# Altium schematic sheet outputs.SchDoc
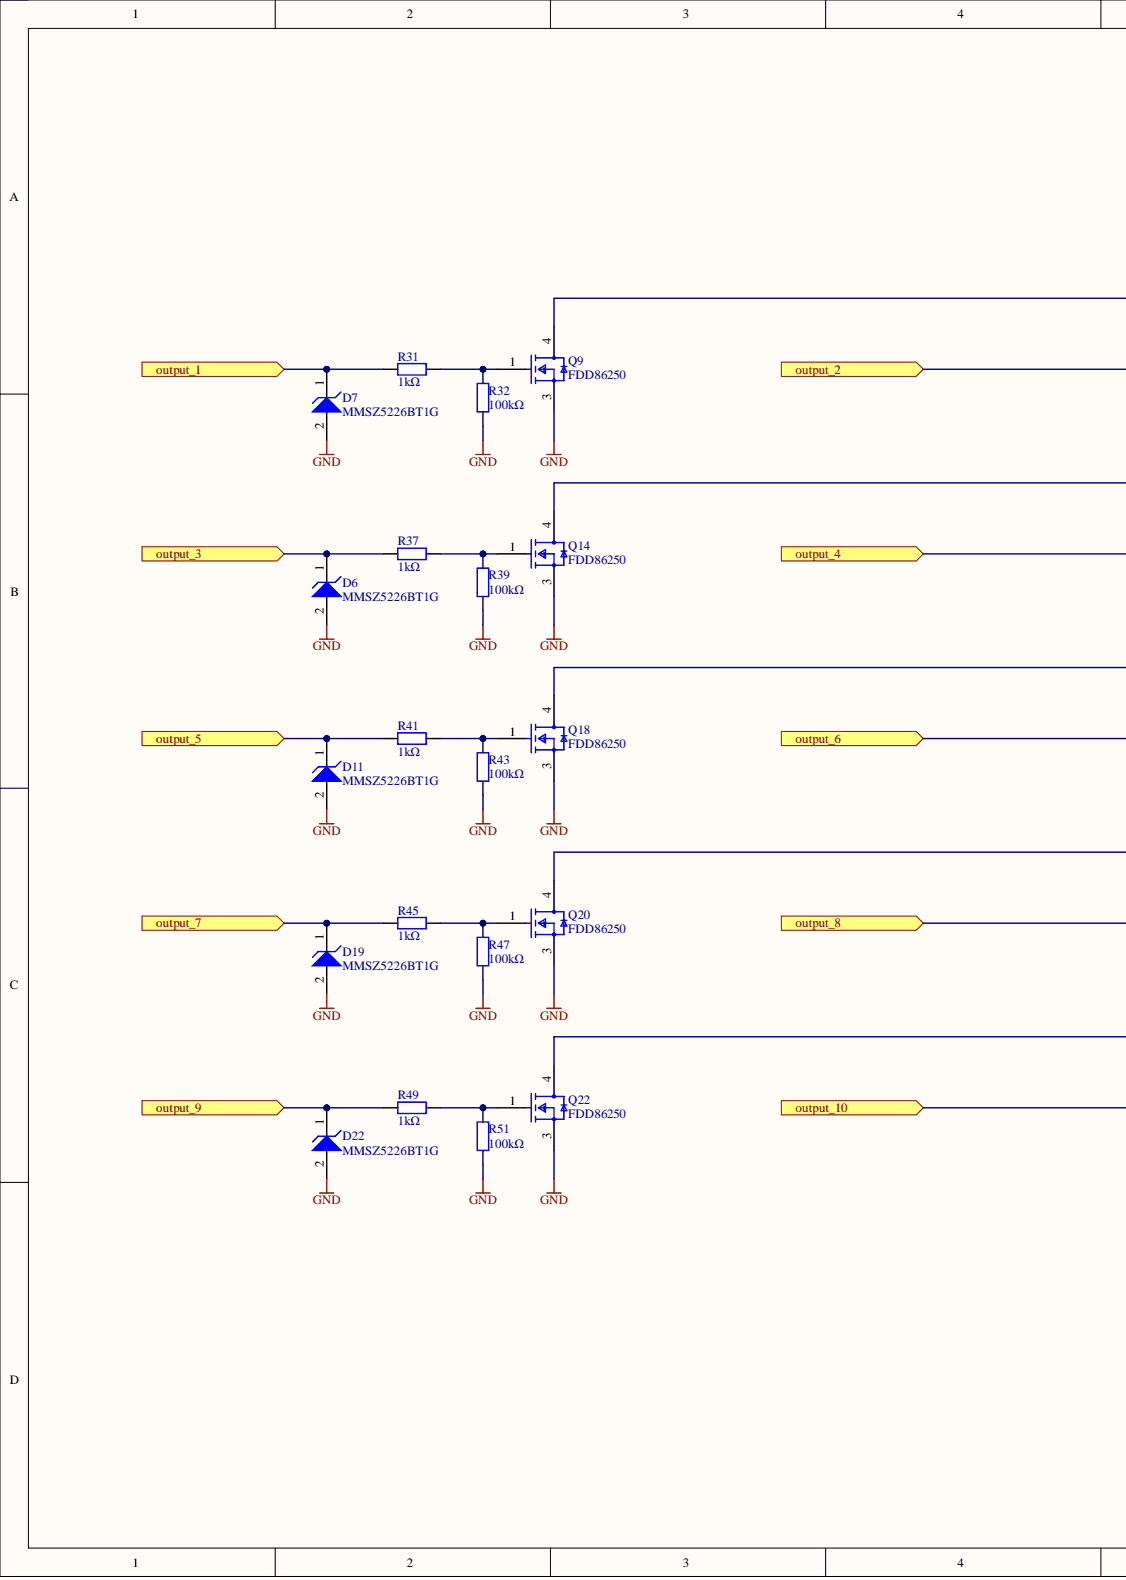
[diagram p0: outputs.SchDoc, left]
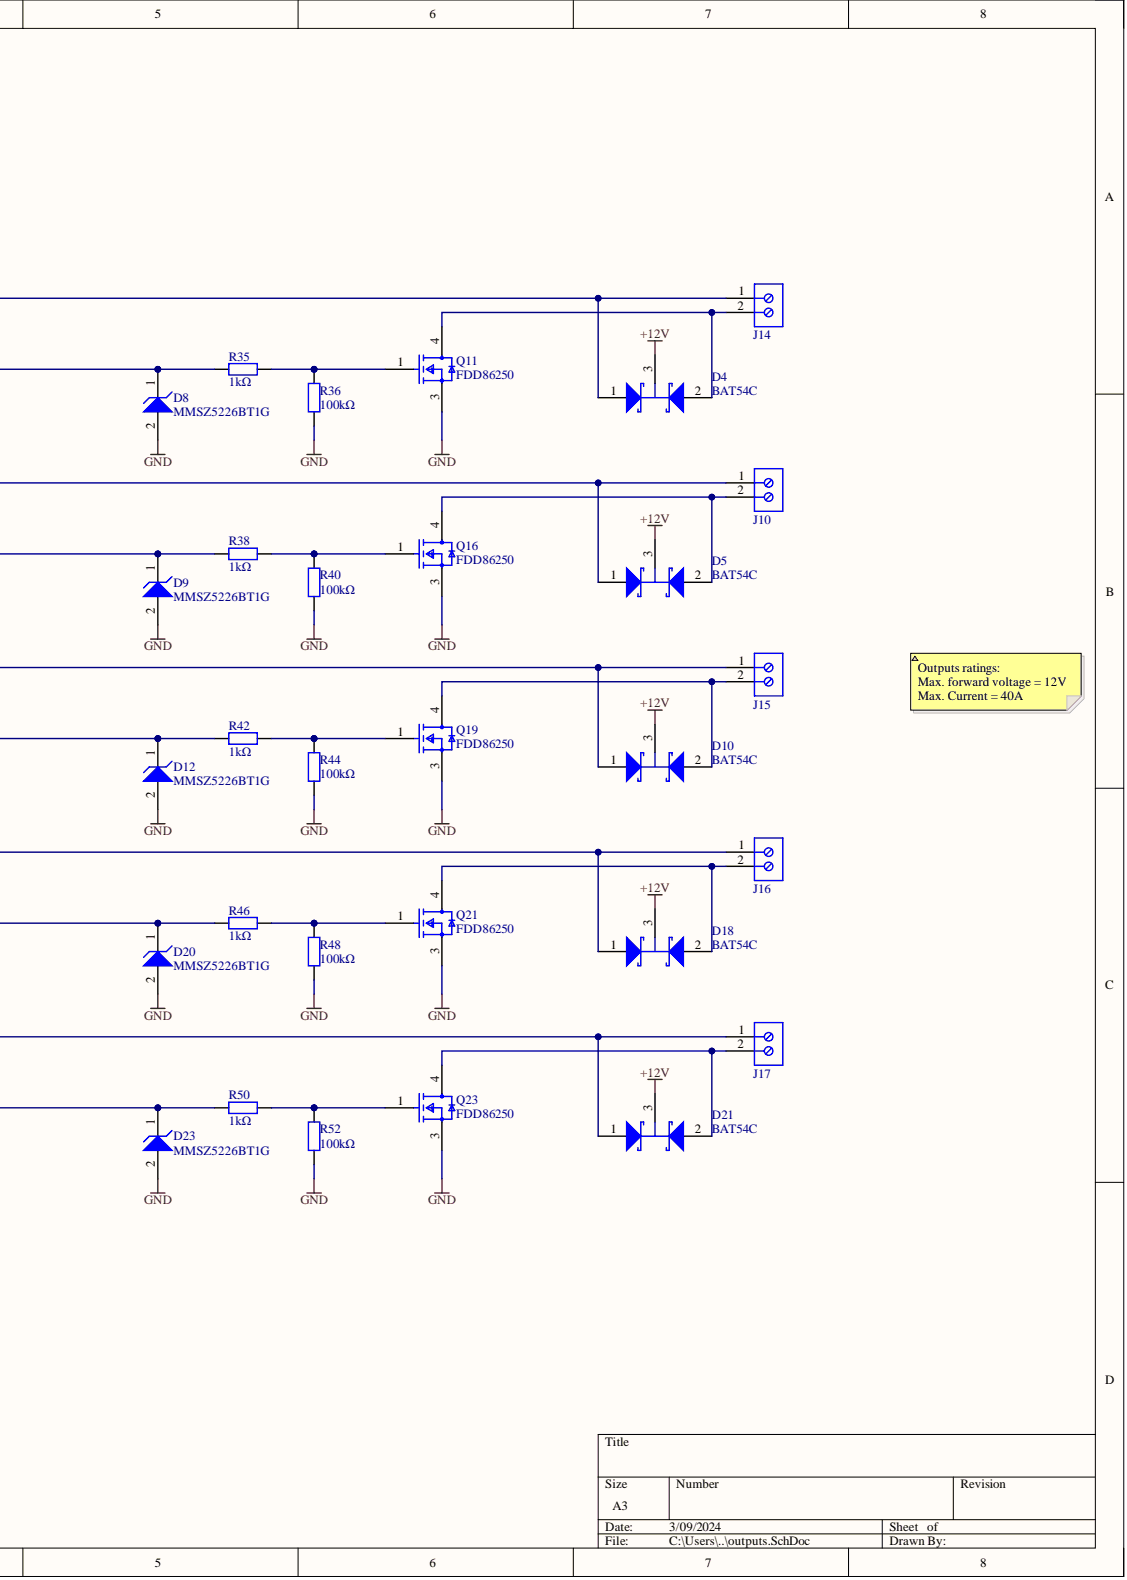
[diagram p1: outputs.SchDoc, right]

## Parts
D4  BAT54C  [SOT-23]
D5  BAT54C  [SOT-23]
D6  MMSZ5226BT1G  [ONSC-SOD-123-2-425-04_V]
D7  MMSZ5226BT1G  [ONSC-SOD-123-2-425-04_V]
D8  MMSZ5226BT1G  [ONSC-SOD-123-2-425-04_V]
D9  MMSZ5226BT1G  [ONSC-SOD-123-2-425-04_V]
D10  BAT54C  [SOT-23]
D11  MMSZ5226BT1G  [ONSC-SOD-123-2-425-04_V]
D12  MMSZ5226BT1G  [ONSC-SOD-123-2-425-04_V]
D18  BAT54C  [SOT-23]
D19  MMSZ5226BT1G  [ONSC-SOD-123-2-425-04_V]
D20  MMSZ5226BT1G  [ONSC-SOD-123-2-425-04_V]
D21  BAT54C  [SOT-23]
D22  MMSZ5226BT1G  [ONSC-SOD-123-2-425-04_V]
D23  MMSZ5226BT1G  [ONSC-SOD-123-2-425-04_V]
J10  282836-2  [TECO-282836-2_V]  (value not drawn)
J14  282836-2  [TECO-282836-2_V]  (value not drawn)
J15  282836-2  [TECO-282836-2_V]  (value not drawn)
J16  282836-2  [TECO-282836-2_V]  (value not drawn)
J17  282836-2  [TECO-282836-2_V]  (value not drawn)
Q9  FDD86250  [FP-369AS-MFG]
Q11  FDD86250  [FP-369AS-MFG]
Q14  FDD86250  [FP-369AS-MFG]
Q16  FDD86250  [FP-369AS-MFG]
Q18  FDD86250  [FP-369AS-MFG]
Q19  FDD86250  [FP-369AS-MFG]
Q20  FDD86250  [FP-369AS-MFG]
Q21  FDD86250  [FP-369AS-MFG]
Q22  FDD86250  [FP-369AS-MFG]
Q23  FDD86250  [FP-369AS-MFG]
R31  1kΩ  [RESC2013X50X30ML20T20]
R32  100kΩ  [RESC2013X50X30ML20T20]
R35  1kΩ  [RESC2013X50X30ML20T20]
R36  100kΩ  [RESC2013X50X30ML20T20]
R37  1kΩ  [RESC2013X50X30ML20T20]
R38  1kΩ  [RESC2013X50X30ML20T20]
R39  100kΩ  [RESC2013X50X30ML20T20]
R40  100kΩ  [RESC2013X50X30ML20T20]
R41  1kΩ  [RESC2013X50X30ML20T20]
R42  1kΩ  [RESC2013X50X30ML20T20]
R43  100kΩ  [RESC2013X50X30ML20T20]
R44  100kΩ  [RESC2013X50X30ML20T20]
R45  1kΩ  [RESC2013X50X30ML20T20]
R46  1kΩ  [RESC2013X50X30ML20T20]
R47  100kΩ  [RESC2013X50X30ML20T20]
R48  100kΩ  [RESC2013X50X30ML20T20]
R49  1kΩ  [RESC2013X50X30ML20T20]
R50  1kΩ  [RESC2013X50X30ML20T20]
R51  100kΩ  [RESC2013X50X30ML20T20]
R52  100kΩ  [RESC2013X50X30ML20T20]

## Ports
output_1, output_2, output_3, output_4, output_5, output_6, output_7, output_8, output_9, output_10

## Nets
+12V: D4.3 D5.3 D10.3 D18.3 D21.3
GND: D6.2 D7.2 D8.2 D9.2 D11.2 D12.2 D19.2 D20.2 D22.2 D23.2 Q9.3 Q11.3 Q14.3 Q16.3 Q18.3 Q19.3 Q20.3 Q21.3 Q22.3 Q23.3 R32.1 R36.1 R39.1 R40.1 R43.1 R44.1 R47.1 R48.1 R51.1 R52.1
output_1: D7.1 R31.1 ; port output_1
output_2: D8.1 R35.1 ; port output_2
output_3: D6.1 R37.1 ; port output_3
output_4: D9.1 R38.1 ; port output_4
output_5: D11.1 R41.1 ; port output_5
output_6: D12.1 R42.1 ; port output_6
output_7: D19.1 R45.1 ; port output_7
output_8: D20.1 R46.1 ; port output_8
output_9: D22.1 R49.1 ; port output_9
output_10: D23.1 R50.1 ; port output_10
NetD4_1: D4.1 J14.1 Q9.4
NetD4_2: D4.2 J14.2 Q11.4
NetD5_1: D5.1 J10.1 Q14.4
NetD5_2: D5.2 J10.2 Q16.4
NetD10_1: D10.1 J15.1 Q18.4
NetD10_2: D10.2 J15.2 Q19.4
NetD18_1: D18.1 J16.1 Q20.4
NetD18_2: D18.2 J16.2 Q21.4
NetD21_1: D21.1 J17.1 Q22.4
NetD21_2: D21.2 J17.2 Q23.4
NetQ9_1: Q9.1 R31.2 R32.2
NetQ11_1: Q11.1 R35.2 R36.2
NetQ14_1: Q14.1 R37.2 R39.2
NetQ16_1: Q16.1 R38.2 R40.2
NetQ18_1: Q18.1 R41.2 R43.2
NetQ19_1: Q19.1 R42.2 R44.2
NetQ20_1: Q20.1 R45.2 R47.2
NetQ21_1: Q21.1 R46.2 R48.2
NetQ22_1: Q22.1 R49.2 R51.2
NetQ23_1: Q23.1 R50.2 R52.2

## Library data of the parts
D4 D5 D10 D18 D21 = DIODE_BAT54C: Schottky Barrier Diode, 30 V, 3-Pin SOT23, RoHS, Tape and Reel; Manufacturer: NXP Semiconductors; Common Connection: Cathode; Current Ifsm: 600mA; Forward Current If(AV): 200mA; Forward Voltage: 800mV; Junction Temperature Tj Max: 125degC; No. of Pins: 3; Package Version: Feb-16; Pin Configuration: 1A1,2A2,3comK; Reel Quantity: 3000; Repetitive Reverse Voltage Vrrm Max: 30V; Reverse Recovery Time trr Max: 5ns; SMD Marking: L43/*W1; SVHC: NoSVHC(19-Dec-2012); Component Kind: Standard; Datasheet URL: https://datasheet.ciiva.com/33723/1668625-33723936.pdf; Datasheet Version: Rev. 5 , 10/2012; Diode Case Style: SOT-23; Diode Type: Schottky; Forward Surge Current Ifsm Max: 600mA; Forward Voltage VF Max: 800mV; Library Name: integrated_library.IntLib; Manufacturer URL: http://www.nxp.com/; Operating Temperature Max: 150degC; Operating Temperature Min: -65degC; Operating Temperature Range: -65degCto+150degC; Package / Case: SOT-23; Package Description: 3-Pin Small Outline Transistor, Body 1.3 x 2.9 mm, Pitch 0.95 mm; Package Reference: SOT23-3; Package URL: http://www.nxp.com/documents/outline_drawing/SOT23.pdf; Reverse Recovery Time trr Typ: 5ns; Tape Width: 8mm; Termination Type: SMD
D6-D9 D11 D12 D19 D20 D22 D23 = CMP-1060-00363-1: Zener Voltage Regulator, 500 mW, 2-Pin SOD-123, Pb-Free, Tape and Reel; Manufacturer: On Semiconductor; P Max (W): 0.5; VZ Typ (V): 3.3; Component Kind: Standard; ComponentLink1Description: Manufacturer URL; ComponentLink1URL: http://www.onsemi.com/; ComponentLink2Description: Datasheet; ComponentLink2URL: http://www.onsemi.com/pub_link/Collateral/MMSZ5221BT1-D.PDF; ComponentLink3Description: Package Specification; ComponentLink3URL: http://www.onsemi.com/pub_link/Collateral/425-04.PDF; DatasheetVersion: Rev. 12; Library Name: Altium Content Vault; Mounting Technology: Surface Mount; PackageDescription: 2-Pin SOD, Body 1.6 x 2.69 mm; PackageReference: SOD-123-2-425-04; PackageVersion: Rev. G, 10/2009; Packing: Tape and Reel; PartNumber: MMSZ5226BT1G; RoHS: Pb-Free
J10 J14-J17 = CMP-1684-00017-1: Female Header, Pitch 5 mm, 1 x 2 Position, Height 10 mm, Tail Length 3.5 mm, Rohs, Bulk; Manufacturer: TE Connectivity; 3D Model: 282836-2.pdf; Clamp Material - Plating: Brass-NickelPlated; Current: 13.5A; Dynamic Catalog: BuchananSeries; Featured Product: EurostyleTerminalBlockConnectors; Mating Orientation: HorizontalwithBoard; Number of Levels: 1; Other Names: 5ULV-02B  A98076; Pitch: 0.197"(5.00mm); Positions Per Level: 2; Product Training Modules: Wire-to-BoardEurostyleTerminalBlocks; Screw Thread: M3; Torque - Screw: 0.68Nm(6Lb-In); Voltage: 300V; Wire Gauge: 16-30AWG; Wire Termination: Screw-RisingCageClamp; Category: Connectors,Interconnects; Color: Green; Component Kind: Standard; Contact Material - Plating: Brass-TinPlated; Datasheet URL: http://www.te.com.cn/commerce/DocumentDelivery/DDEController?Action=showdoc&DocId=Catalog+Section%7F1308389_EUROSTYLE_TERMINAL_BLOCKS%7F0607%7Fpdf%7FEnglish%7FENG_CS_1308389_EUROSTYLE_TERMINAL_BLOCKS_0607.pdf%7F282836-2; Family: TerminalBlocks-WiretoBoard; Features: Interlocking(Side); Housing Material: Polyamide(PA66),Nylon6/6; Library Name: Altium Content Vault; Manufacturer URL: http://www.te.com; Mounting Type: ThroughHole; Package Description: Female Header, Pitch 5 mm, 1 x 2 Position, Height 10 mm, Tail Length 3.5 mm; Package Reference: THD; Packaging: Bulk; RoHS Information: 282836-2StatementofCompliance; Screw Material - Plating: Brass-NickelPlated; Series: Buchanan; Standard Package: 300
Q9 Q11 Q14 Q16 Q18-Q23 = CMP-07173-000037-1: MOSFET N-CH 150V 8A DPAK; Manufacturer: ON Semiconductor; Depletion Mode: False; Drain To Source Resistance: 18.4mΩ; Gate Charge At Vgs: 23nC @ 10V; Gate To Source Voltage: 20V; Input Capacitace At Vds: 1585pF @ 75V; Max Forward Diode Voltage: 1.3V; Max Junction Temp: +150°C; Number Of Channels: 1; Power Dissipation: 3.1W; Pulse Drain Current: 164A; Reverse Recovery Charge: 104nC; Reverse Recovery Time: 71ns; Rthja Max: 40°C/W; Threshold Vgs Max: 4V; Threshold Vgs Min: 2V; Transconductance: 28S; Turn Off Delay Time: 20ns; Turn On Delay Time: 11.2ns; Typ Forward Diode Voltage: 0.78V; Automotive: No; Category: Trans; Component Kind: Standard; ComponentLink2Description: Datasheet; ComponentLink2URL: https://www.onsemi.com/pub/Collateral/FDD86250-D.pdf; Continuous Drain Current: 8A; Device Class L1: Discrete Semiconductors; Device Class L2: Transistors; Device Class L3: MOSFETs; Digikey Description: MOSFET N-CH 150V 8A DPAK; Drain To Source Breakdown Voltage: 150V; Drain To Source Voltage: 150V; Footprint Url: https://www.onsemi.com/pub/Collateral/369AS.PDF; Height: 2.517mm; Lead Free: Yes; Library Name: Altium Content Vault; Manufacturer Part Number: FDD86250; Mouser Description: Shielded Gate PowerTrench® MOSFET, N-Channel, 150 V, 51 A, 22 mO; Number Of N Channels: 1; Octopart: https://octopart.com/fdd86250-on+semiconductor-84325855; Package: 369AS; RoHS: TRUE; Temperature: -55°C to +150°C; Temperature Range High: +150°C; Temperature Range Low: -55°C
R31 R32 R35-R52 = Res_0805: Resistor; Component Kind: Standard; Library Name: PCB_Project.SchLib; Signal Integrity: Res; Simulation: RESISTOR
